# Altium schematic sheet 2_LEDs_rB0.SchDoc
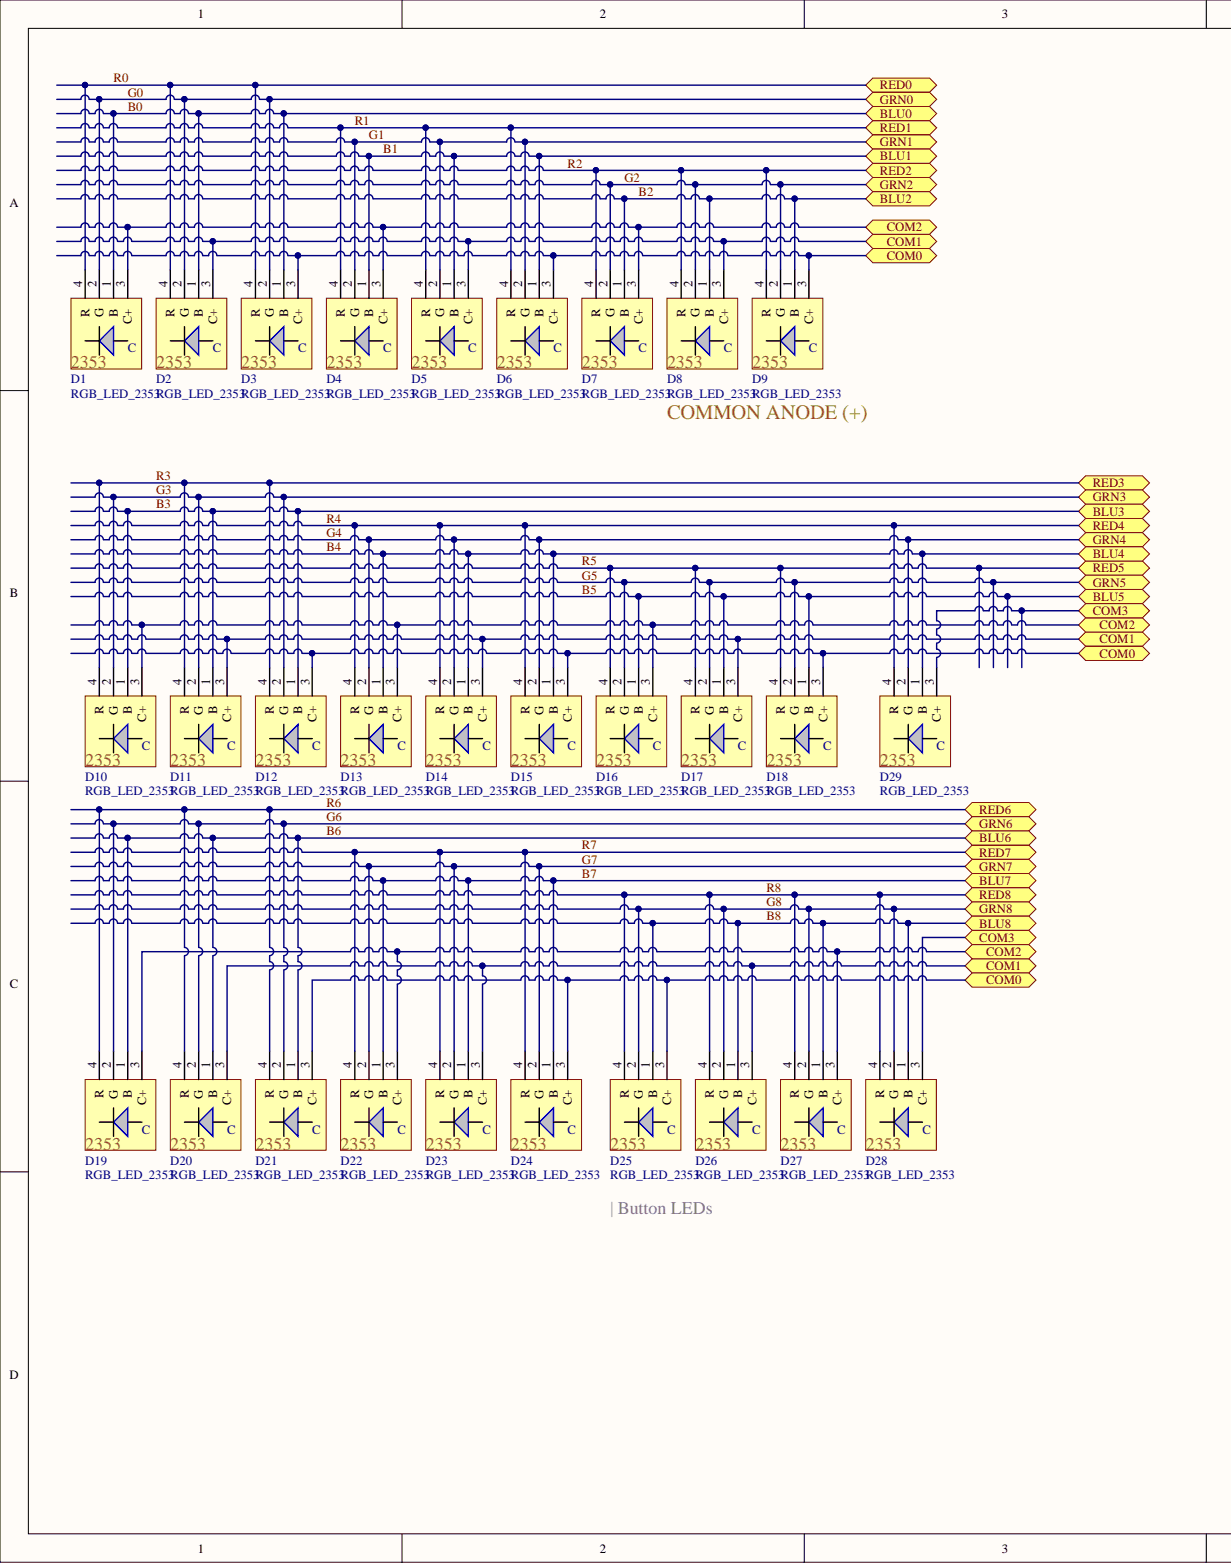
[diagram p0: 2_LEDs_rB0.SchDoc, left]
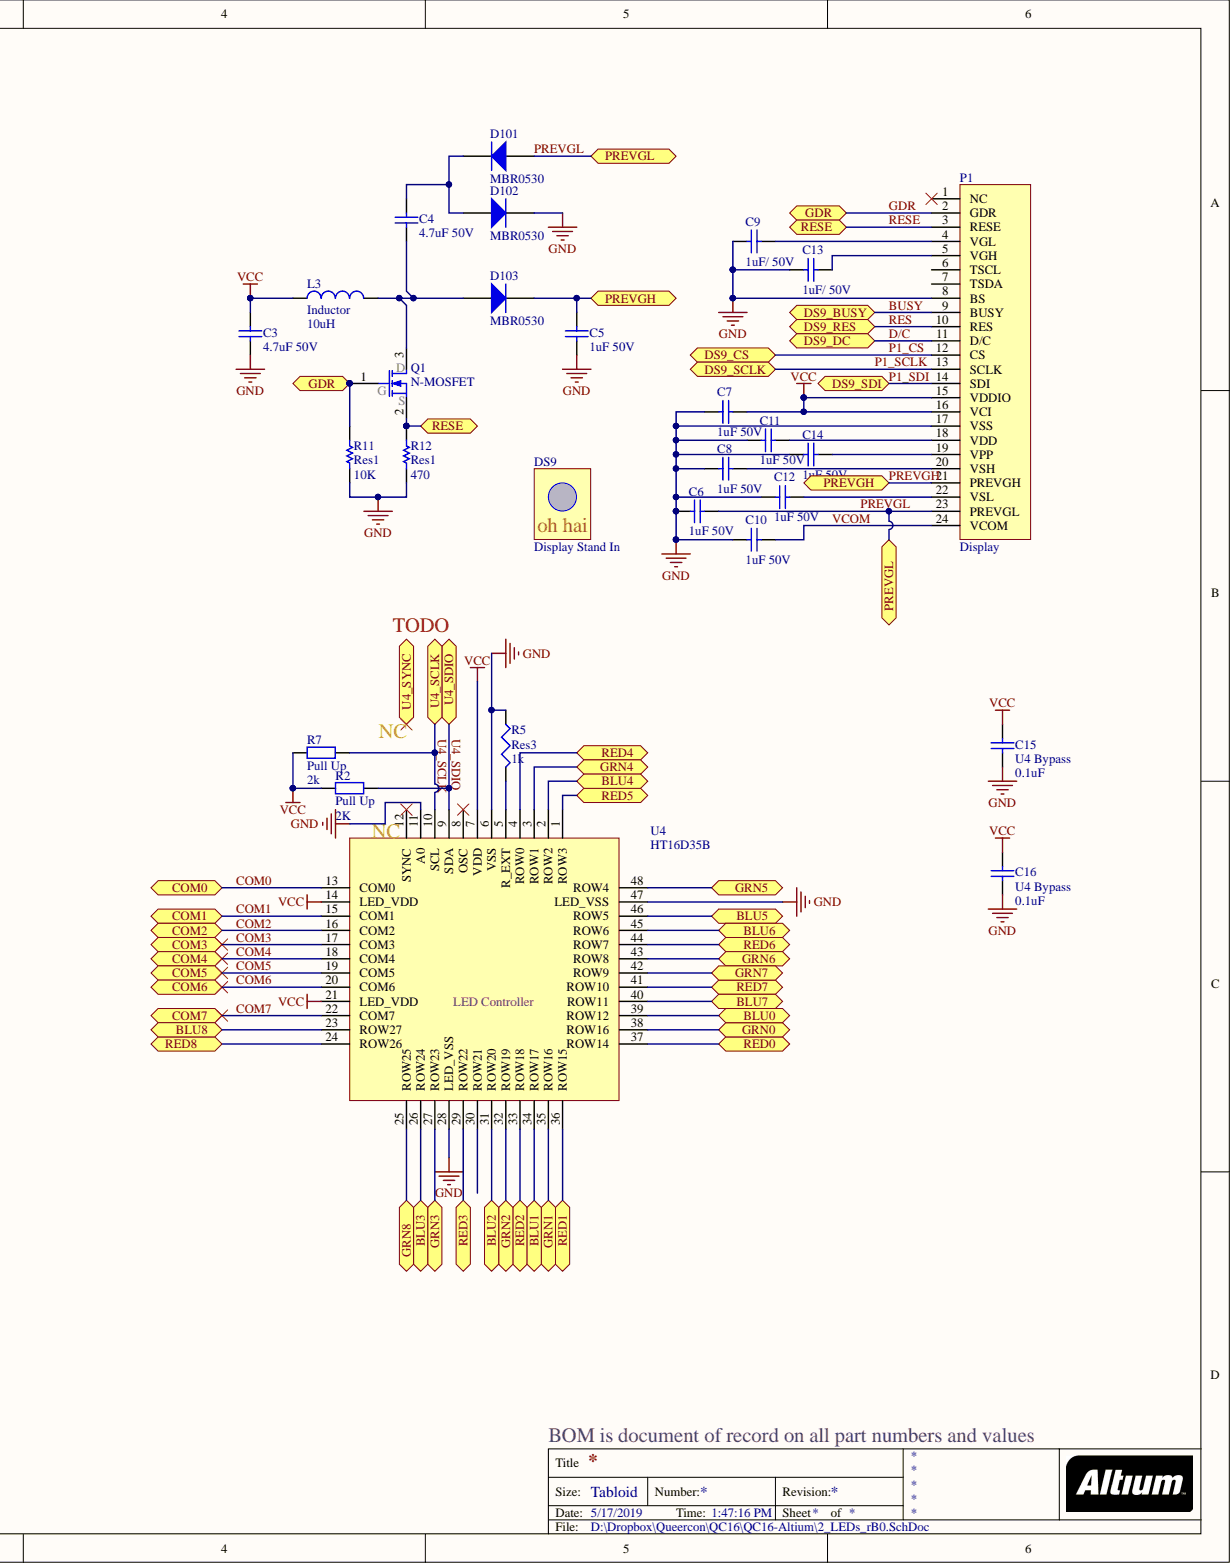
[diagram p1: 2_LEDs_rB0.SchDoc, right]

## Parts
C3  value 4.7uF 50V  [CAPC1608(0603)]  (hidden comment: Cap)
C4  value 4.7uF 50V  [CAPC1608(0603)]  (hidden comment: Cap)
C5  value 1uF 50V  [CAPC1608(0603)]  (hidden comment: Cap)
C6  value 1uF 50V  [CAPC1608(0603)]  (hidden comment: Cap)
C7  value 1uF 50V  [CAPC1608(0603)]  (hidden comment: Cap)
C8  value 1uF 50V  [CAPC1608(0603)]  (hidden comment: Cap)
C9  value 1uF/ 50V  [CAPC1608(0603)]  (hidden comment: Cap)
C10  value 1uF 50V  [CAPC1608(0603)]  (hidden comment: Cap)
C11  value 1uF 50V  [CAPC1608(0603)]  (hidden comment: Cap)
C12  value 1uF 50V  [CAPC1608(0603)]  (hidden comment: Cap)
C13  value 1uF/ 50V  [CAPC1608(0603)]  (hidden comment: Cap)
C14  value 1uF 50V  [CAPC1608(0603)]  (hidden comment: Cap)
C15  U4 Bypass  value 0.1uF  [CAPC1608(0603)]
C16  U4 Bypass  value 0.1uF  [CAPC1608(0603)]
D1  RGB_LED_2353  [HQ12-2353RGBC]
D2  RGB_LED_2353  [HQ12-2353RGBC]
D3  RGB_LED_2353  [HQ12-2353RGBC]
D4  RGB_LED_2353  [HQ12-2353RGBC]
D5  RGB_LED_2353  [HQ12-2353RGBC]
D6  RGB_LED_2353  [HQ12-2353RGBC]
D7  RGB_LED_2353  [HQ12-2353RGBC]
D8  RGB_LED_2353  [HQ12-2353RGBC]
D9  RGB_LED_2353  [HQ12-2353RGBC]
D10  RGB_LED_2353  [HQ12-2353RGBC]
D11  RGB_LED_2353  [HQ12-2353RGBC]
D12  RGB_LED_2353  [HQ12-2353RGBC]
D13  RGB_LED_2353  [HQ12-2353RGBC]
D14  RGB_LED_2353  [HQ12-2353RGBC]
D15  RGB_LED_2353  [HQ12-2353RGBC]
D16  RGB_LED_2353  [HQ12-2353RGBC]
D17  RGB_LED_2353  [HQ12-2353RGBC]
D18  RGB_LED_2353  [HQ12-2353RGBC]
D19  RGB_LED_2353  [HQ19-2331BGRC]
D20  RGB_LED_2353  [HQ19-2331BGRC]
D21  RGB_LED_2353  [HQ19-2331BGRC]
D22  RGB_LED_2353  [HQ19-2331BGRC]
D23  RGB_LED_2353  [HQ19-2331BGRC]
D24  RGB_LED_2353  [HQ19-2331BGRC]
D25  RGB_LED_2353  [HQ19-2331BGRC]
D26  RGB_LED_2353  [HQ19-2331BGRC]
D27  RGB_LED_2353  [HQ19-2331BGRC]
D28  RGB_LED_2353  [HQ19-2331BGRC]
D29  RGB_LED_2353  [HQ19-2331BGRC]
D101  MBR0530  [SOD-123]
D102  MBR0530  [SOD-123]
D103  MBR0530  [SOD-123]
DS9  Display Stand In  [Display]
L3  Inductor  value 10uH  [C1210_M]
P1  Display  [Edge Connector 24 TOP]
Q1  N-MOSFET  [SOT-323]
R2  Pull Up  value 2K  [RESC1608(0603)]
R5  Res3  value 1k  [J1-0603]
R7  Pull Up  value 2k  [RESC1608(0603)]
R11  Res1  value 10K  [RESC1608(0603)]
R12  Res1  value 470  [RESC1608(0603)]
U4  HT16D35B  [TSQFP51P899X899X160-48L]

## Ports
BLU0, BLU1, BLU2, BLU3, BLU4, BLU5, BLU6, BLU7, BLU8, COM0, COM1, COM2, COM3, COM4, COM5, COM6, COM7, DS9_BUSY, DS9_CS, DS9_DC, DS9_RES, DS9_SCLK, DS9_SDI, GDR, GRN0, GRN1, GRN2, GRN3, GRN4, GRN5, GRN6, GRN7, GRN8, PREVGH, PREVGL, RED0, RED1, RED2, RED3, RED4, RED5, RED6, RED7, RED8, RESE, U4_SCLK, U4_SDIO, U4_SYNC

## Nets
GND: C3.1 C5.1 C6.2 C7.2 C8.2 C9.1 C10.2 C11.2 C12.2 C13.1 C14.2 C15.1 C16.1 D102.2 P1.8(BS) P1.17(VSS) R5.1 R11.1 R12.1 U4.6(VSS) U4.11(A0) U4.28(LED_VSS) U4.47(LED_VSS)
VCC: C3.2 C7.1 C15.2 C16.2 L3.1 P1.15(VDDIO) P1.16(VCI) R2.1 R7.1 U4.7(VDD) U4.14(LED_VDD) U4.21(LED_VDD)
B0: D1.1(B) D2.1(B) D3.1(B) U4.39(ROW12) ; port BLU0
B1: D4.1(B) D5.1(B) D6.1(B) U4.34(ROW17) ; port BLU1
B2: D7.1(B) D8.1(B) D9.1(B) U4.31(ROW20) ; port BLU2
B3: D10.1(B) D11.1(B) D12.1(B) U4.26(ROW24) ; port BLU3
B4: D13.1(B) D14.1(B) D15.1(B) D29.1(B) U4.2(ROW2) ; port BLU4
B5: D16.1(B) D17.1(B) D18.1(B) U4.46(ROW5) ; port BLU5
B6: D19.1(B) D20.1(B) D21.1(B) U4.45(ROW6) ; port BLU6
B7: D22.1(B) D23.1(B) D24.1(B) U4.40(ROW11) ; port BLU7
B8: D25.1(B) D26.1(B) D27.1(B) D28.1(B) U4.23(ROW27) ; port BLU8
BUSY: P1.9(BUSY) ; port DS9_BUSY
COM0: D3.3(C+) D6.3(C+) D9.3(C+) D12.3(C+) D15.3(C+) D18.3(C+) D21.3(C+) D24.3(C+) D25.3(C+) U4.13(COM0) ; port COM0
COM1: D2.3(C+) D5.3(C+) D8.3(C+) D11.3(C+) D14.3(C+) D17.3(C+) D20.3(C+) D23.3(C+) D26.3(C+) U4.15(COM1) ; port COM1
COM2: D1.3(C+) D4.3(C+) D7.3(C+) D10.3(C+) D13.3(C+) D16.3(C+) D19.3(C+) D22.3(C+) D27.3(C+) U4.16(COM2) ; port COM2
COM3: D28.3(C+) D29.3(C+) U4.17(COM3) ; port COM3
COM4: U4.18(COM4) ; port COM4
COM5: U4.19(COM5) ; port COM5
COM6: U4.20(COM6) ; port COM6
COM7: U4.22(COM7) ; port COM7
D/C: P1.11(D/C) ; port DS9_DC
G0: D1.2(G) D2.2(G) D3.2(G) U4.38(ROW16) ; port GRN0
G1: D4.2(G) D5.2(G) D6.2(G) U4.35(ROW16) ; port GRN1
G2: D7.2(G) D8.2(G) D9.2(G) U4.32(ROW19) ; port GRN2
G3: D10.2(G) D11.2(G) D12.2(G) U4.27(ROW23) ; port GRN3
G4: D13.2(G) D14.2(G) D15.2(G) D29.2(G) U4.3(ROW1) ; port GRN4
G5: D16.2(G) D17.2(G) D18.2(G) U4.48(ROW4) ; port GRN5
G6: D19.2(G) D20.2(G) D21.2(G) U4.43(ROW8) ; port GRN6
G7: D22.2(G) D23.2(G) D24.2(G) U4.42(ROW9) ; port GRN7
G8: D25.2(G) D26.2(G) D27.2(G) D28.2(G) U4.25(ROW25) ; port GRN8
GDR: P1.2(GDR) Q1.1 R11.2 ; port GDR
P1_CS: P1.12(CS) ; port DS9_CS
P1_SCLK: P1.13(SCLK) ; port DS9_SCLK
P1_SDI: P1.14(SDI) ; port DS9_SDI
PREVGH: C5.2 D103.2 P1.21(PREVGH) ; port PREVGH
PREVGL: C6.1 D101.1 P1.23(PREVGL) ; port PREVGL
R0: D1.4(R) D2.4(R) D3.4(R) U4.37(ROW14) ; port RED0
R1: D4.4(R) D5.4(R) D6.4(R) U4.36(ROW15) ; port RED1
R2: D7.4(R) D8.4(R) D9.4(R) U4.33(ROW18) ; port RED2
R3: D10.4(R) D11.4(R) D12.4(R) U4.29(ROW22) ; port RED3
R4: D13.4(R) D14.4(R) D15.4(R) D29.4(R) U4.4(ROW0) ; port RED4
R5: D16.4(R) D17.4(R) D18.4(R) U4.1(ROW3) ; port RED5
R6: D19.4(R) D20.4(R) D21.4(R) U4.44(ROW7) ; port RED6
R7: D22.4(R) D23.4(R) D24.4(R) U4.41(ROW10) ; port RED7
R8: D25.4(R) D26.4(R) D27.4(R) D28.4(R) U4.24(ROW26) ; port RED8
RES: P1.10(RES) ; port DS9_RES
RESE: P1.3(RESE) Q1.2 R12.2 ; port RESE
U4_SCLK: R7.2 U4.10(SCL) ; port U4_SCLK
U4_SDIO: R2.2 U4.9(SDA) ; port U4_SDIO
VCOM: C10.1 P1.24(VCOM)
NetC4_1: C4.1 D103.1 L3.2 Q1.3
NetC4_2: C4.2 D101.2 D102.1
NetC8_1: C8.1 P1.20(VSH)
NetC9_2: C9.2 P1.4(VGL)
NetC11_1: C11.1 P1.18(VDD)
NetC12_1: C12.1 P1.22(VSL)
NetC13_2: C13.2 P1.5(VGH)
NetC14_1: C14.1 P1.19(VPP)
NetR5_2: R5.2 U4.5(R_EXT)
N00081: ; port U4_SYNC

Not connected: P1 1(NC) 6(TSCL) 7(TSDA); U4 8(OSC) 12(SYNC) 30(ROW21)

## Library data of the parts
C3 C5-C8 C10-C16 = Cap: Capacitor; Component Kind: Standard; LatestRevisionDate: 17-Jul-2002; LatestRevisionNote: Re-released for DXP Platform.; Library Name: Miscellaneous Devices.IntLib; PackageDescription: Radial Cap, Thru-Hole; 2 Leads; 0.3 in Pin Spacing; PackageReference: RAD-0.3; Published: 8-Jun-2000; Signal Integrity: Cap; Simulation: CAP; Publisher: Altium Limited
C4 = Cap: Capacitor; Value: 4.7uF 50V; Component Kind: Standard; LatestRevisionDate: 17-Jul-2002; LatestRevisionNote: Re-released for DXP Platform.; Library Name: Miscellaneous Devices.IntLib; PackageDescription: Radial Cap, Thru-Hole; 2 Leads; 0.3 in Pin Spacing; PackageReference: RAD-0.3; Published: 8-Jun-2000; Signal Integrity: Cap; Simulation: CAP; Publisher: Altium Limited
C9 = Cap: Capacitor; Value: 1uF/ 50V; Component Kind: Standard; LatestRevisionDate: 17-Jul-2002; LatestRevisionNote: Re-released for DXP Platform.; Library Name: Miscellaneous Devices.IntLib; PackageDescription: Radial Cap, Thru-Hole; 2 Leads; 0.3 in Pin Spacing; PackageReference: RAD-0.3; Published: 8-Jun-2000; Signal Integrity: Cap; Simulation: CAP; Publisher: Altium Limited
D101-D103 = Diode 1N914: High Conductance Fast Diode; Code_JEDEC: DO-204-AH; Component Kind: Standard; ComponentLink1Description: Manufacturer Link; ComponentLink1URL: http://www.fairchildsemi.com/; ComponentLink2Description: Datasheet; ComponentLink2URL: http://www.fairchildsemi.com/ds/1N%2F1N4448.pdf; DatasheetVersion: 1997; LatestRevisionDate: 17-Jul-2002; LatestRevisionNote: Re-released for DXP Platform.; Library Name: Miscellaneous Devices.IntLib; PackageDescription: Diode, Axial; Body 3.8 x 1.9 mm (LxDia. max), Lead Dia. 0.53 mm (max); PackageReference: DO-35; Published: 8-Jun-2000; Signal Integrity: 1N914; Simulation: 1N914; Publisher: Altium Limited
L3 = Inductor: Code_IEC: 1005; Code_JEITA: 0402; Component Kind: Standard; Description: Inductor; LatestRevisionDate: 29-May-2009; LatestRevisionNote: IPC-7351 Footprint Added.; Library Name: Miscellaneous Devices.IntLib; PackageDescription: Chip Inductor; PackageReference: 0402-A; Published: 8-Jun-2000; Signal Integrity: Inductor; Simulation: INDUCTOR; Publisher: Altium Limited
R2 = Res2: Resistor; Value: 2K; Component Kind: Standard; LatestRevisionDate: 17-Jul-2002; LatestRevisionNote: Re-released for DXP Platform.; Library Name: Miscellaneous Devices.IntLib; PackageDescription: Axial Device, Thru-Hole; 2 Leads; 0.4 in Pin Spacing; PackageReference: AXIAL-0.4; Published: 8-Jun-2000; Signal Integrity: Res; Simulation: RESISTOR; Publisher: Altium Limited
R5 = Res3: Resistor; Component Kind: Standard; LatestRevisionDate: 29-May-2009; LatestRevisionNote: IPC-7351 Footprint Added.; Library Name: Miscellaneous Devices.IntLib; PackageDescription: Chip Resistor; PackageReference: J1-0603; Published: 8-Jun-2000; Signal Integrity: Res; Simulation: RESISTOR; Publisher: Altium Limited
R7 = Res2: Resistor; Value: 2k; Component Kind: Standard; LatestRevisionDate: 17-Jul-2002; LatestRevisionNote: Re-released for DXP Platform.; Library Name: Miscellaneous Devices.IntLib; PackageDescription: Axial Device, Thru-Hole; 2 Leads; 0.4 in Pin Spacing; PackageReference: AXIAL-0.4; Published: 8-Jun-2000; Signal Integrity: Res; Simulation: RESISTOR; Publisher: Altium Limited
R11 R12 = Res1: Resistor; Component Kind: Standard; LatestRevisionDate: 17-Jul-2002; LatestRevisionNote: Re-released for DXP Platform.; Library Name: Miscellaneous Devices.IntLib; PackageDescription: Axial Device, Thru-Hole; 2 Leads; 0.3 in Pin Spacing; PackageReference: AXIAL-0.3; Published: 8-Jun-2000; Signal Integrity: Res; Simulation: RESISTOR; Publisher: Altium Limited
U4 = LED Controller QFP: Component Kind: Standard; Library Name: 2018SchematicLibrary.SchLib
D1-D29 = RGB_LED_2353: Component Kind: Standard; Library Name: 2018SchematicLibrary.SchLib
DS9 = Display Stand In: Component Kind: Standard; Library Name: Lib_2019.SchLib
P1 = Display: Component Kind: Standard; Library Name: Lib_2019.SchLib
Q1 = N-MOSFET: Component Kind: Standard; Library Name: Lib_2019.SchLib
